# Altium schematic sheet [3] TopLevel.SchDoc("[3] TopLevel")
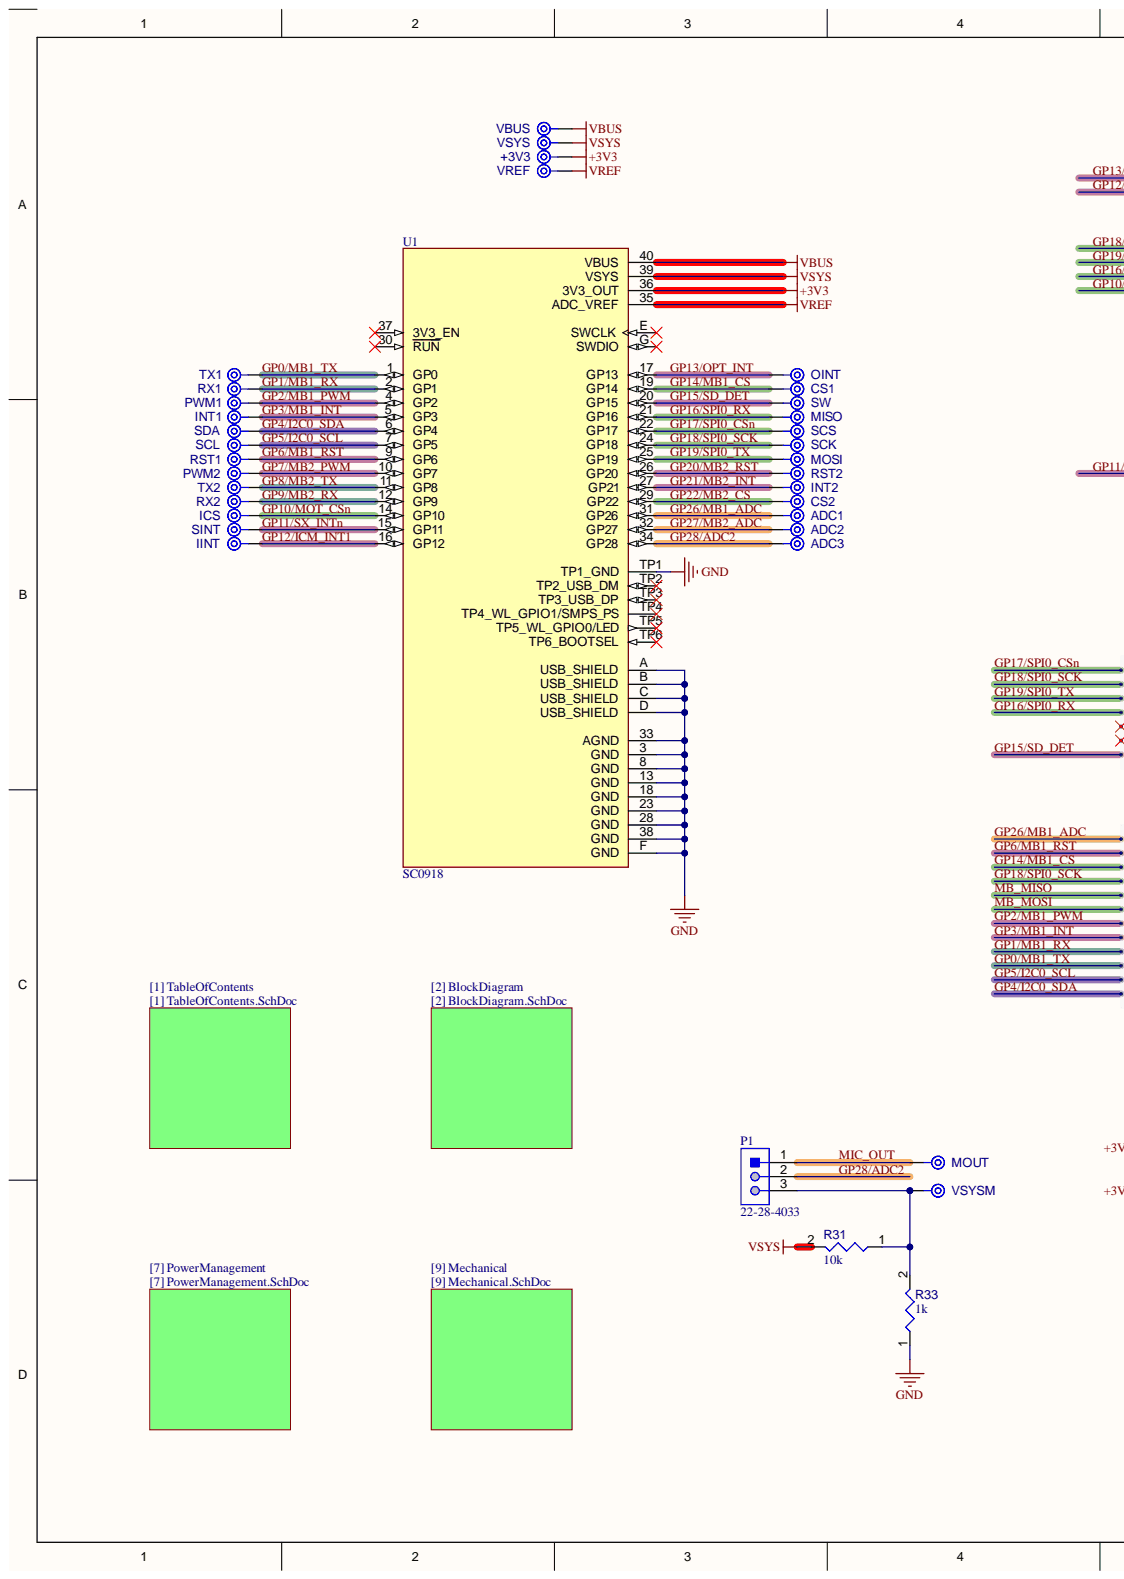
[diagram p0: [3] TopLevel.SchDoc, left]
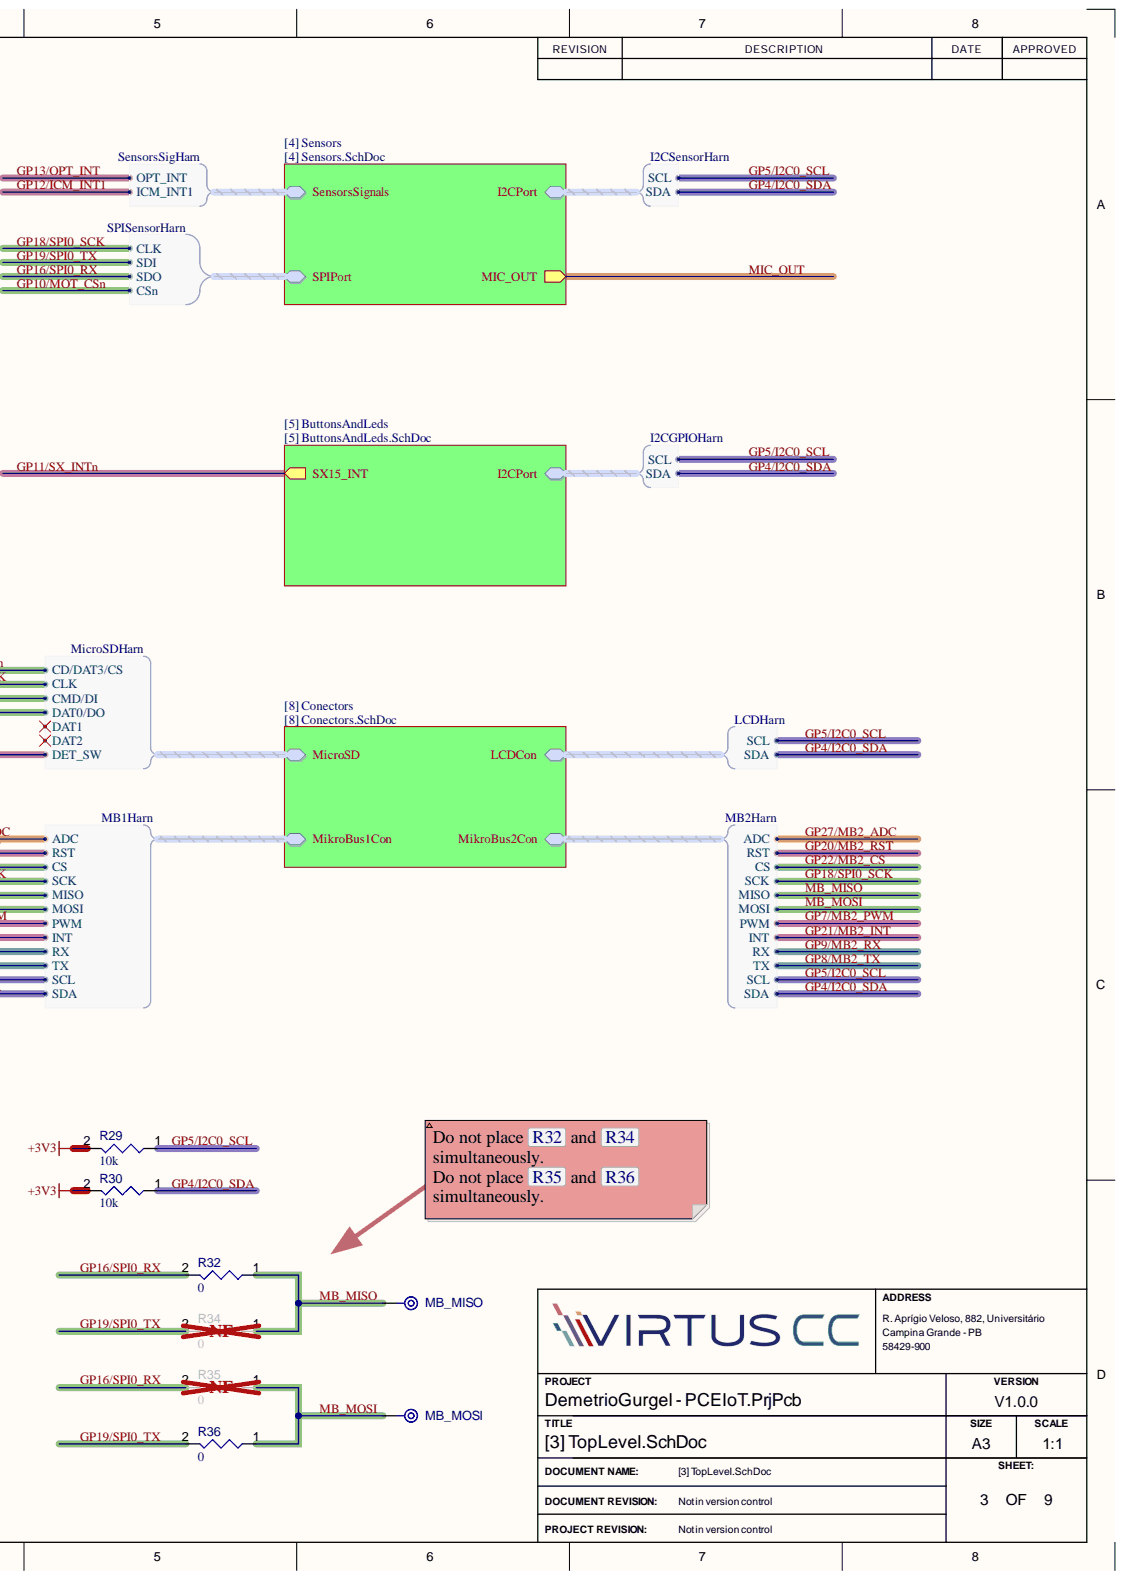
[diagram p1: [3] TopLevel.SchDoc, right]

## Parts
+3V3  TPAD6R  [TP06R]  (value not drawn)  (no BOM)
ADC1  TPAD6R  [TP06R]  (value not drawn)  (no BOM)
ADC2  TPAD6R  [TP06R]  (value not drawn)  (no BOM)
ADC3  TPAD6R  [TP06R]  (value not drawn)  (no BOM)
CS1  TPAD6R  [TP06R]  (value not drawn)  (no BOM)
CS2  TPAD6R  [TP06R]  (value not drawn)  (no BOM)
ICS  TPAD6R  [TP06R]  (value not drawn)  (no BOM)
IINT  TPAD6R  [TP06R]  (value not drawn)  (no BOM)
INT1  TPAD6R  [TP06R]  (value not drawn)  (no BOM)
INT2  TPAD6R  [TP06R]  (value not drawn)  (no BOM)
MB_MISO  TPAD6R  [TP06R]  (value not drawn)  (no BOM)
MB_MOSI  TPAD6R  [TP06R]  (value not drawn)  (no BOM)
MISO  TPAD6R  [TP06R]  (value not drawn)  (no BOM)
MOSI  TPAD6R  [TP06R]  (value not drawn)  (no BOM)
MOUT  TPAD6R  [TP06R]  (value not drawn)  (no BOM)
OINT  TPAD6R  [TP06R]  (value not drawn)  (no BOM)
P1  22-28-4033  [MOLX-22-28-4033_V]
PWM1  TPAD6R  [TP06R]  (value not drawn)  (no BOM)
PWM2  TPAD6R  [TP06R]  (value not drawn)  (no BOM)
R29  value 10k  [RESC0603(1608)_L]  (hidden comment: Resistor 10k +/-1% 0603 100 mW)
R30  value 10k  [RESC0603(1608)_L]  (hidden comment: Resistor 10k +/-1% 0603 100 mW)
R31  value 10k  [RESC0603(1608)_L]  (hidden comment: Resistor 10k +/-1% 0603 100 mW)
R32  value 0  [RESC0603(1608)_L]  (hidden comment: Resistor 0R +/- 5% 0603 100 mW)
R33  value 1k  [RESC0603(1608)_L]  (hidden comment: Resistor 1k +/-1% 0603 100 mW)
R34  value 0  [RESC0603(1608)_L]  (hidden comment: Resistor 0R +/- 5% 0603 100 mW)
R35  value 0  [RESC0603(1608)_L]  (hidden comment: Resistor 0R +/- 5% 0603 100 mW)
R36  value 0  [RESC0603(1608)_L]  (hidden comment: Resistor 0R +/- 5% 0603 100 mW)
RST1  TPAD6R  [TP06R]  (value not drawn)  (no BOM)
RST2  TPAD6R  [TP06R]  (value not drawn)  (no BOM)
RX1  TPAD6R  [TP06R]  (value not drawn)  (no BOM)
RX2  TPAD6R  [TP06R]  (value not drawn)  (no BOM)
SCK  TPAD6R  [TP06R]  (value not drawn)  (no BOM)
SCL  TPAD6R  [TP06R]  (value not drawn)  (no BOM)
SCS  TPAD6R  [TP06R]  (value not drawn)  (no BOM)
SDA  TPAD6R  [TP06R]  (value not drawn)  (no BOM)
SINT  TPAD6R  [TP06R]  (value not drawn)  (no BOM)
SW  TPAD6R  [TP06R]  (value not drawn)  (no BOM)
TX1  TPAD6R  [TP06R]  (value not drawn)  (no BOM)
TX2  TPAD6R  [TP06R]  (value not drawn)  (no BOM)
U1  SC0918  [MODULE_SC0918]
VBUS  TPAD6R  [TP06R]  (value not drawn)  (no BOM)
VREF  TPAD6R  [TP06R]  (value not drawn)  (no BOM)
VSYS  TPAD6R  [TP06R]  (value not drawn)  (no BOM)
VSYSM  TPAD6R  [TP06R]  (value not drawn)  (no BOM)

## Nets
+3V3: +3V3.1 R29.2 R30.2 U1.36(3V3_OUT)
GND: R33.1 U1.3(GND) U1.8(GND) U1.13(GND) U1.18(GND) U1.23(GND) U1.28(GND) U1.33(AGND) U1.38(GND) U1.A(USB_SHIELD) U1.B(USB_SHIELD) U1.C(USB_SHIELD) U1.D(USB_SHIELD) U1.F(GND) U1.TP1(TP1_GND)
VBUS: U1.40(VBUS) VBUS.1
VREF: U1.35(ADC_VREF) VREF.1
VSYS: R31.2 U1.39(VSYS) VSYS.1
GP0/MB1_TX: TX1.1 U1.1(GP0)
GP1/MB1_RX: RX1.1 U1.2(GP1)
GP2/MB1_PWM: PWM1.1 U1.4(GP2)
GP3/MB1_INT: INT1.1 U1.5(GP3)
GP4/I2C0_SDA: R30.1 SDA.1 U1.6(GP4)
GP5/I2C0_SCL: R29.1 SCL.1 U1.7(GP5)
GP6/MB1_RST: RST1.1 U1.9(GP6)
GP7/MB2_PWM: PWM2.1 U1.10(GP7)
GP8/MB2_TX: TX2.1 U1.11(GP8)
GP9/MB2_RX: RX2.1 U1.12(GP9)
GP10/MOT_CSn: ICS.1 U1.14(GP10)
GP11/SX_INTn: SINT.1 U1.15(GP11)
GP12/ICM_INT1: IINT.1 U1.16(GP12)
GP13/OPT_INT: OINT.1 U1.17(GP13)
GP14/MB1_CS: CS1.1 U1.19(GP14)
GP15/SD_DET: SW.1 U1.20(GP15)
GP16/SPI0_RX: MISO.1 R32.2 R35.2 U1.21(GP16)
GP17/SPI0_CSn: SCS.1 U1.22(GP17)
GP18/SPI0_SCK: SCK.1 U1.24(GP18)
GP19/SPI0_TX: MOSI.1 R34.2 R36.2 U1.25(GP19)
GP20/MB2_RST: RST2.1 U1.26(GP20)
GP21/MB2_INT: INT2.1 U1.27(GP21)
GP22/MB2_CS: CS2.1 U1.29(GP22)
GP26/MB1_ADC: ADC1.1 U1.31(GP26)
GP27/MB2_ADC: ADC2.1 U1.32(GP27)
GP28/ADC2: ADC3.1 P1.2 U1.34(GP28)
MB_MISO: MB_MISO.1 R32.1 R34.1
MB_MOSI: MB_MOSI.1 R35.1 R36.1
MIC_OUT: MOUT.1 P1.1
NetP1_3: P1.3 R31.1 R33.2 VSYSM.1
NetU1_30: U1.30(RUN)
NetU1_37: U1.37(3V3_EN)
NetU1_E: U1.E(SWCLK)
NetU1_G: U1.G(SWDIO)
NetU1_TP2: U1.TP2(TP2_USB_DM)
NetU1_TP3: U1.TP3(TP3_USB_DP)
NetU1_TP4: U1.TP4(TP4_WL_GPIO1/SMPS_PS)
NetU1_TP5: U1.TP5(TP5_WL_GPIO0/LED)
NetU1_TP6: U1.TP6(TP6_BOOTSEL)
MicroSD.DAT1:
MicroSD.DAT2:

## Library data of the parts
+3V3 ADC1-ADC3 CS1 CS2 ICS IINT INT1 INT2 MB_MISO MB_MOSI MISO MOSI MOUT OINT PWM1 PWM2 RST1 RST2 RX1 RX2 SCK SCL SCS SDA SINT SW TX1 TX2 VBUS VREF VSYS VSYSM = CMP-00028-00002-2: 0.6mm round; Pins: 1; Library Name: UFCG - Universidade Federal de Campina Grande
P1 = CMP-002-00076-1: KK 254 Breakaway Header Vertical 3 Circuits 0.38um Gold Selective Plating Mating Pin 6.09mm; Contact Resistance: 20mR; Current Rating: 4A; Gender: Male; Glow Wire Compliant: Non-compliant; Max Voltage Rating (AC): 250V; Number of Circuits: 3; Pitch: 2.54mm; Color: Black; Component Kind: Standard; Contact Material: Brass,Bronze; Contact Mating Length: 0.24inch; Contact Plating: Gold; Contact Type: Male Pin; Depth: 2.49mm; Flammability Rating: UL94 V-0; Housing Color: Black; Housing Material: Nylon; Lead Free: Lead Free; Max Operating Temperature: 75°C; Max Voltage Rating (DC): 250V; Min Operating Temperature: 0°C; Mounting Technology: Through Hole; Number of Contacts: 3; Number of Positions: 3; Number of Rows: 1; Orientation: Straight; Package Quantity: 1; Packaging: Bulk; Radiation Hardening: No; Reach SVHC Compliant: Unknown; RoHS Compliant: Yes; Series: KK® 42375; Termination: Solder; Voltage Rating: 250V; Voltage Rating (DC): 250V; Library Name: UFCG - Universidade Federal de Campina Grande
R29-R31 = CMP-009-00017-7: Chip Resistor, 10 KOhm, +/- 1%, 01 W, -55 to 155 degC, 0603 (1608 Metric); Power: 100mW; Voltage Rating: 50V; Case/Package: 0603; Component Kind: Standard; Max Operating Temperature: 155°C; Min Operating Temperature: -55°C; Mounting Technology: SM; Pins: 2; RoHS Compliant: True; Tolerance: 1%; Library Name: UFCG - Universidade Federal de Campina Grande
R32 R34-R36 = CMP-009-00006-6: Chip Resistor, 0 Ohm, 0.1 W, -55 to 155 degC, 0603 (1608 Metric); Power: 100mW; Voltage Rating: 50V; Case/Package: 0603; Component Kind: Standard; Max Operating Temperature: 155°C; Min Operating Temperature: -55°C; Mounting Technology: SM; Pins: 2; RoHS Compliant: True; Tolerance: 5%; Library Name: UFCG - Universidade Federal de Campina Grande
R33 = CMP-009-00014-6: Chip Resistor, 1 KOhm, +/-1%, 0.1 W, -55 to 155 degC, 0603 (1608 Metric); Power: 100mW; Tolerance: 1%; Voltage Rating: 50V; Case/Package: 0603; Component Kind: Standard; Max Operating Temperature: 155°C; Min Operating Temperature: -55°C; Mounting Technology: SM; Pins: 2; RoHS Compliant: True; Library Name: UFCG - Universidade Federal de Campina Grande
U1 = CMP-006-000001-6: Raspberry Pi Pico W RP2040; Availability: In Stock; Check_prices: https://www.snapeda.com/parts/SC0918/Raspberry+Pi/view-part/?ref=eda; Component Kind: Standard; Component Type: Standard; Design Item ID: SC0918; MF: Raspberry Pi; MP: SC0918; Package: None; Pin Count: 53; Price: None; Purchase-URL: https://pricing.snapeda.com/search/part/SC0918/?ref=eda; SnapEDA_Link: https://www.snapeda.com/parts/SC0918/Raspberry+Pi/view-part/?ref=snap; Source: SC0918.SchLib; Library Name: UFCG - Universidade Federal de Campina Grande
